# Altium schematic sheet 2-Power.schdoc(2-Power)
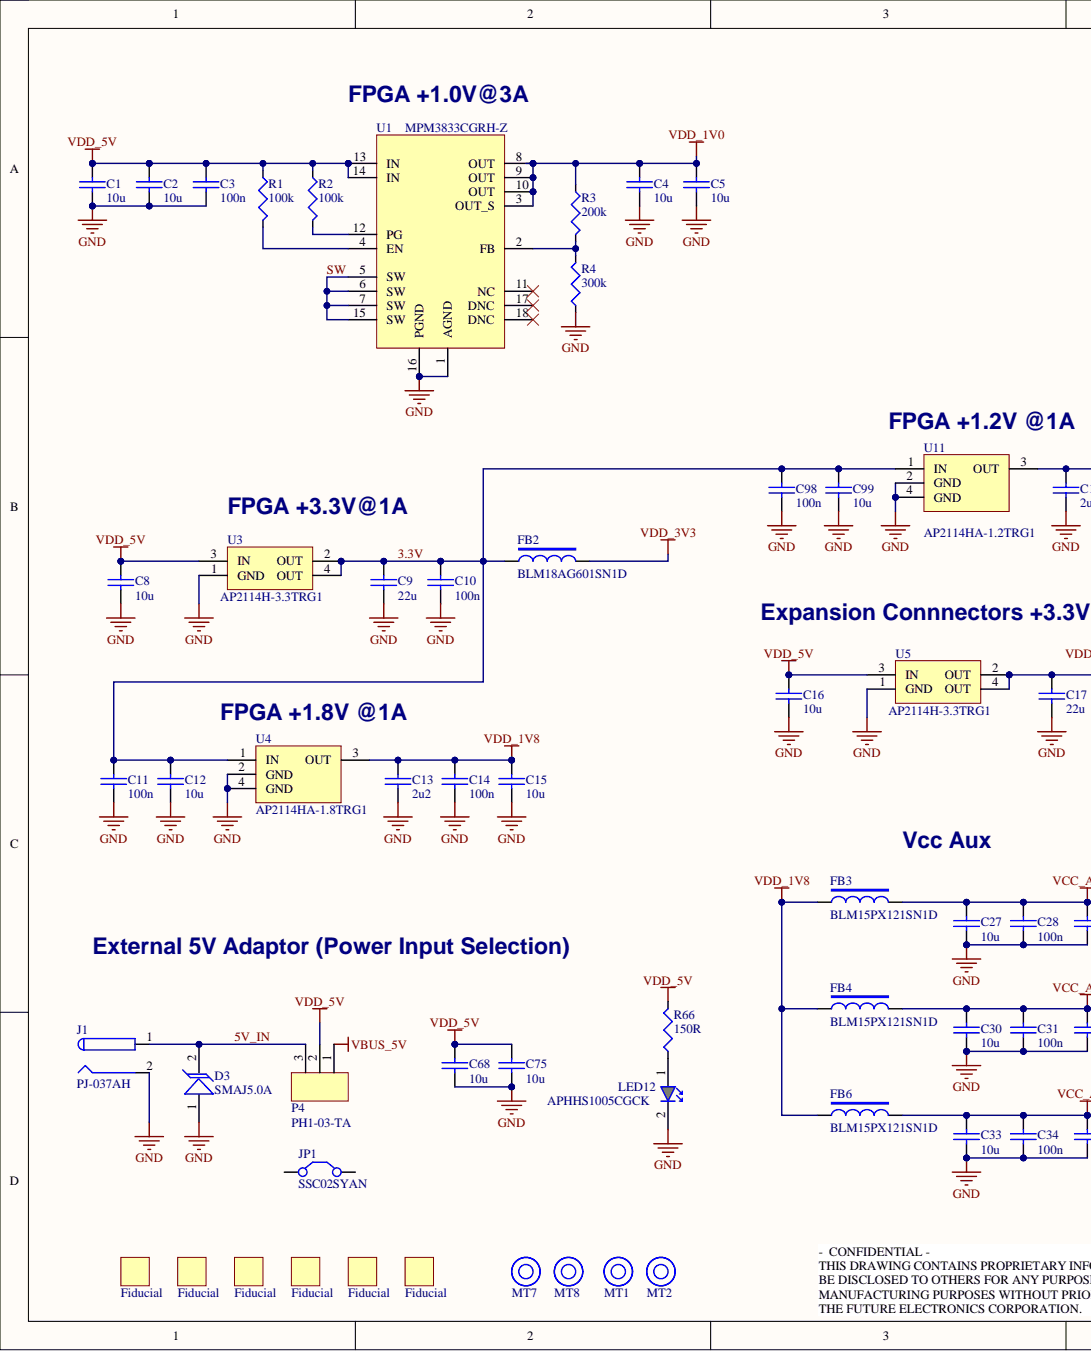
[diagram p0: 2-Power.schdoc, left]
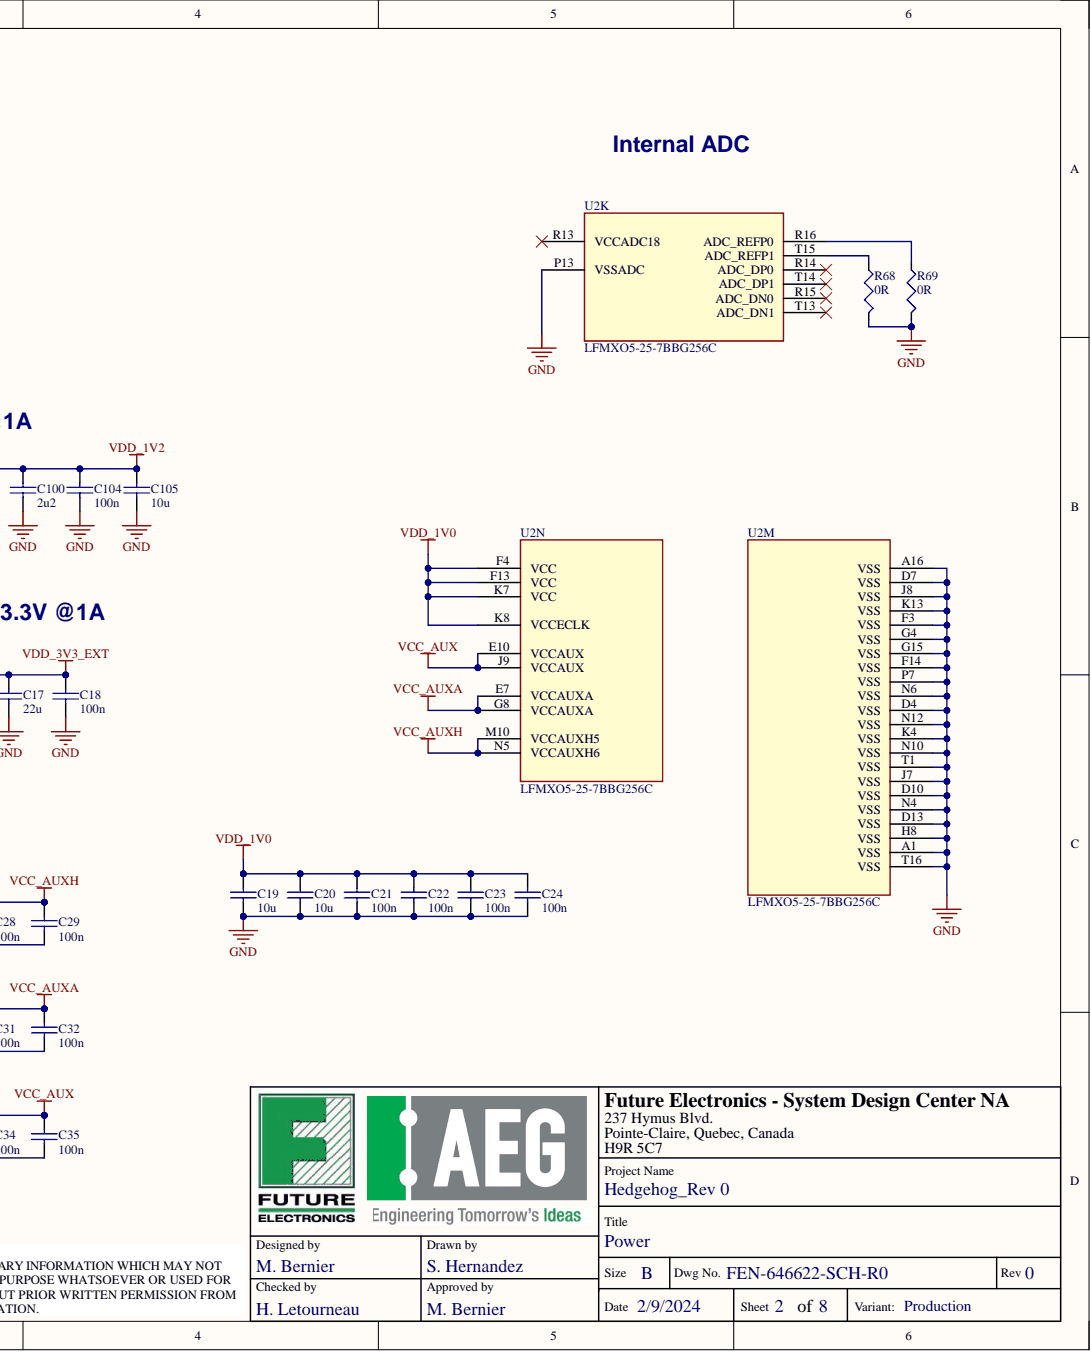
[diagram p1: 2-Power.schdoc, right]

## Parts
C1  value 10u  [1206]  (hidden comment: C-10uF-50V-Cer-1206_A)
C2  value 10u  [1206]  (hidden comment: C-10uF-50V-Cer-1206_A)
C3  value 100n  [0402]  (hidden comment: C-100nF-50V-Cer-0402)
C4  value 10u  [1206]  (hidden comment: C-10uF-50V-Cer-1206_A)
C5  value 10u  [1206]  (hidden comment: C-10uF-50V-Cer-1206_A)
C8  value 10u  [1206]  (hidden comment: C-10uF-50V-Cer-1206_A)
C9  value 22u  [0603]  (hidden comment: C-22uF-16V-Cer-0603)
C10  value 100n  [0402]  (hidden comment: C-100nF-50V-Cer-0402)
C11  value 100n  [0402]  (hidden comment: C-100nF-50V-Cer-0402)
C12  value 10u  [1206]  (hidden comment: C-10uF-50V-Cer-1206_A)
C13  value 2u2  [0805]  (hidden comment: C-2.2uF-10V-Cer-0805)
C14  value 100n  [0402]  (hidden comment: C-100nF-50V-Cer-0402)
C15  value 10u  [1206]  (hidden comment: C-10uF-50V-Cer-1206_A)
C16  value 10u  [1206]  (hidden comment: C-10uF-50V-Cer-1206_A)
C17  value 22u  [0603]  (hidden comment: C-22uF-16V-Cer-0603)
C18  value 100n  [0402]  (hidden comment: C-100nF-50V-Cer-0402)
C19  value 10u  [1206]  (hidden comment: C-10uF-50V-Cer-1206_A)
C20  value 10u  [1206]  (hidden comment: C-10uF-50V-Cer-1206_A)
C21  value 100n  [0402]  (hidden comment: C-100nF-50V-Cer-0402)
C22  value 100n  [0402]  (hidden comment: C-100nF-50V-Cer-0402)
C23  value 100n  [0402]  (hidden comment: C-100nF-50V-Cer-0402)
C24  value 100n  [0402]  (hidden comment: C-100nF-50V-Cer-0402)
C27  value 10u  [1206]  (hidden comment: C-10uF-50V-Cer-1206_A)
C28  value 100n  [0402]  (hidden comment: C-100nF-50V-Cer-0402)
C29  value 100n  [0402]  (hidden comment: C-100nF-50V-Cer-0402)
C30  value 10u  [1206]  (hidden comment: C-10uF-50V-Cer-1206_A)
C31  value 100n  [0402]  (hidden comment: C-100nF-50V-Cer-0402)
C32  value 100n  [0402]  (hidden comment: C-100nF-50V-Cer-0402)
C33  value 10u  [1206]  (hidden comment: C-10uF-50V-Cer-1206_A)
C34  value 100n  [0402]  (hidden comment: C-100nF-50V-Cer-0402)
C35  value 100n  [0402]  (hidden comment: C-100nF-50V-Cer-0402)
C68  value 10u  [1206]  (hidden comment: C-10uF-50V-Cer-1206_A)
C75  value 10u  [1206]  (hidden comment: C-10uF-50V-Cer-1206_A)
C98  value 100n  [0402]  (hidden comment: C-100nF-50V-Cer-0402)
C99  value 10u  [1206]  (hidden comment: C-10uF-50V-Cer-1206_A)
C100  value 2u2  [0805]  (hidden comment: C-2.2uF-10V-Cer-0805)
C104  value 100n  [0402]  (hidden comment: C-100nF-50V-Cer-0402)
C105  value 10u  [1206]  (hidden comment: C-10uF-50V-Cer-1206_A)
D3  MFR PN: SMAJ5.0A  [SMA]  (hidden comment: D-SMAJ5.0A)
FB2  MFR PN: BLM18AG601SN1D  [0603]  (hidden comment: FB-600Ohm@100MHz-0603)
FB3  MFR PN: BLM15PX121SN1D  [0402]  (hidden comment: FB-120Ohm@100MHz-0402)
FB4  MFR PN: BLM15PX121SN1D  [0402]  (hidden comment: FB-120Ohm@100MHz-0402)
FB6  MFR PN: BLM15PX121SN1D  [0402]  (hidden comment: FB-120Ohm@100MHz-0402)
FD1  FD-Fiducial  [Fiducial]  (value not drawn)  (no BOM)
FD2  FD-Fiducial  [Fiducial]  (value not drawn)  (no BOM)
FD3  FD-Fiducial  [Fiducial]  (value not drawn)  (no BOM)
FD4  FD-Fiducial  [Fiducial]  (value not drawn)  (no BOM)
FD5  FD-Fiducial  [Fiducial]  (value not drawn)  (no BOM)
FD6  FD-Fiducial  [Fiducial]  (value not drawn)  (no BOM)
J1  Future PN (TR): PJ-037AH  [J-PJ-037B]  (hidden comment: J-PJ-037AH)
JP1  MFR PN: SSC02SYAN  [No Footprint]  (hidden comment: JP-SSC02SYAN)  (mechanical)
LED12  Future PN (TR): APHHS1005CGCK  [0402-Kingbright]  (hidden comment: LED-APHHS1005CGCK)
MT1  Mount Hole, Unsupported, 2.5mm, Pan Head  [MTG 2.85mm hole]  (value not drawn)  (no BOM)
MT2  Mount Hole, Unsupported, 2.5mm, Pan Head  [MTG 2.85mm hole]  (value not drawn)  (no BOM)
MT7  Mount Hole, Unsupported, 2.5mm, Pan Head  [MTG 2.85mm hole]  (value not drawn)  (no BOM)
MT8  Mount Hole, Unsupported, 2.5mm, Pan Head  [MTG 2.85mm hole]  (value not drawn)  (no BOM)
P4  MFR PN: PH1-03-TA  [HDR100-3PIN-TH]  (hidden comment: P-PH1-03-TA)
R1  value 100k  [0402]  (hidden comment: R-100k-63mW-0402)
R2  value 100k  [0402]  (hidden comment: R-100k-63mW-0402)
R3  value 200k  [0402]  (hidden comment: R-200k-63mW-0402)
R4  value 300k  [0402]  (hidden comment: R-300k-63mW-0402)
R66  value 150R  [0402]  (hidden comment: R-150R-63mW-0402)
R68  value 0R  [0402]  (hidden comment: R-0R-63mW-0402)
R69  value 0R  [0402]  (hidden comment: R-0R-63mW-0402)
U1  Future PN (TR): MPM3833CGRH-Z  [QFN18_MPS]  (hidden comment: U-MPM3833CGRH-Z)
U2  MFR PN: LFMXO5-25-7BBG256C  [BBG256_LATTICE]  (hidden comment: U-LFMXO5-25-7BBG256C)  (parts K,M,N)
U3  Future PN (TR): AP2114H-3.3TRG1  [SOT223]  (hidden comment: U-AP2114H-3.3TRG1)
U4  MFR PN: AP2114HA-1.8TRG1  [SOT223]  (hidden comment: U-AP2114HA-1.8TRG1)
U5  Future PN (TR): AP2114H-3.3TRG1  [SOT223]  (hidden comment: U-AP2114H-3.3TRG1)
U11  MFR PN: AP2114HA-1.2TRG1  [SOT223]  (hidden comment: U-AP2114HA-1.2TRG1)

## Nets
GND: C1.2 C2.2 C3.2 C4.2 C5.2 C8.2 C9.2 C10.2 C11.2 C12.2 C13.2 C14.2 C15.2 C16.2 C17.2 C18.2 C19.2 C20.2 C21.2 C22.2 C23.2 C24.2 C27.2 C28.2 C29.2 C30.2 C31.2 C32.2 C33.2 C34.2 C35.2 C68.2 C75.2 C98.2 C99.2 C100.2 C104.2 C105.2 D3.1 J1.2 LED12.2 R4.1 R68.2 R69.2 U1.1(AGND) U1.16(PGND) U2.A1(VSS) U2.A16(VSS) U2.D4(VSS) U2.D7(VSS) U2.D10(VSS) U2.D13(VSS) U2.F3(VSS) U2.F14(VSS) U2.G4(VSS) U2.G15(VSS) U2.H8(VSS) U2.J7(VSS) U2.J8(VSS) U2.K4(VSS) U2.K13(VSS) U2.N4(VSS) U2.N6(VSS) U2.N10(VSS) U2.N12(VSS) U2.P7(VSS) U2.P13(VSSADC) U2.T1(VSS) U2.T16(VSS) U3.1(GND) U4.2(GND) U4.4(GND) U5.1(GND) U11.2(GND) U11.4(GND)
VBUS_5V: P4.1
VCC_AUX: C33.1 C34.1 C35.1 FB6.2 U2.E10(VCCAUX) U2.J9(VCCAUX)
VCC_AUXA: C30.1 C31.1 C32.1 FB4.2 U2.E7(VCCAUXA) U2.G8(VCCAUXA)
VCC_AUXH: C27.1 C28.1 C29.1 FB3.2 U2.M10(VCCAUXH5) U2.N5(VCCAUXH6)
VDD_1V0: C4.1 C5.1 C19.1 C20.1 C21.1 C22.1 C23.1 C24.1 R3.1 U1.3(OUT_S) U1.8(OUT) U1.9(OUT) U1.10(OUT) U2.F4(VCC) U2.F13(VCC) U2.K7(VCC) U2.K8(VCCECLK)
VDD_1V2: C100.1 C104.1 C105.1 U11.3(OUT)
VDD_1V8: C13.1 C14.1 C15.1 FB3.1 FB4.1 FB6.1 U4.3(OUT)
VDD_3V3: FB2.2
VDD_3V3_EXT: C17.1 C18.1 U5.2(OUT) U5.4(OUT)
VDD_5V: C1.1 C2.1 C3.1 C8.1 C16.1 C68.1 C75.1 P4.2 R1.1 R2.1 R66.2 U1.13(IN) U1.14(IN) U3.3(IN) U5.3(IN)
3.3V: C9.1 C10.1 C11.1 C12.1 C98.1 C99.1 FB2.1 U3.2(OUT) U3.4(OUT) U4.1(IN) U11.1(IN)
5V_IN: D3.2 J1.1 P4.3
SW: U1.5(SW) U1.6(SW) U1.7(SW) U1.15(SW)
NetLED12_1: LED12.1 R66.1
NetR1_2: R1.2 U1.4(EN)
NetR2_2: R2.2 U1.12(PG)
NetR3_2: R3.2 R4.2 U1.2(FB)
NetR68_1: R68.1 U2.T15(ADC_REFP1)
NetR69_1: R69.1 U2.R16(ADC_REFP0)

Not connected: JP1 1 2; U1 11(NC) 17(DNC) 18(DNC); U2 R13(VCCADC18) R14(ADC_DP0) R15(ADC_DN0) T13(ADC_DN1) T14(ADC_DP1)

## Library data of the parts
C1 C2 C4 C5 C8 C12 C15 C16 C19 C20 C27 C30 C33 C68 C75 C99 C105 = C-10uF-50V-Cer-1206_A: Cap 1206 SM X7R 50V 10%; MFR: SAMSUNG; MFR PN: CL31B106KBHNNNE; Future PN (CT): TBD; Future PN (TR): 12065C106KATN; Rating: 50V 10%; Component Kind: Standard; ComponentLink1Description: Datasheet; ComponentLink1URL: http://www.samsungsem.com/kr/support/product-search/mlcc/__icsFiles/afieldfile/2019/06/18/CL31B106KBHNNNE-19.pdf; Library Name: Future_Capacitors_V1.SCHLIB; Verified: AD112817
C3 C10 C11 C14 C18 C21-C24 C28 C29 C31 C32 C34 C35 C98 C104 = C-100nF-50V-Cer-0402: Cap 0402 SM X7R 50V 10%; MFR: TDK; MFR PN: C1005X7R1H104K050BB; Future PN (CT): 04025C104KATN-CT; Future PN (TR): 04025C104KATN; Rating: 50V 10%; Component Kind: Standard; ComponentLink1Description: Datasheet; ComponentLink1URL: http://images.ihscontent.net/vipimages/VipMasterIC/IC/TDKC/TDKC-S-A0000443042/TDKC-S-A0000443042-1.pdf; Library Name: Future_Capacitors_V1.SCHLIB; Verified: AD042616
C9 C17 = C-22uF-16V-Cer-0603: Cap 0603 SM X5R 16V 20%; MFR: SAMSUNG; MFR PN: CL10A226MO7JZNC; Future PN (CT): TBD; Future PN (TR): 0603YD226MATN; Rating: 16V 20%; Component Kind: Standard; ComponentLink1Description: Datasheet; ComponentLink1URL: https://product.samsungsem.com/mlcc/CL10A226MO7JZN.do; Verified: TBD; Library Name: Hedgehog_Rev0.SchLib
C13 C100 = C-2.2uF-10V-Cer-0805: Cap 0805 SM X7R 10V 10%; MFR: AVX; MFR PN: 0805ZC225KAT2A; Future PN (CT): 0805ZC225KATN-CT; Future PN (TR): 0805ZC225KATN; Rating: 10V 10%; Component Kind: Standard; ComponentLink1Description: Datasheet; ComponentLink1URL: http://datasheets.avx.com/X7RDielectric.pdf; Library Name: Future_Capacitors_V1.SCHLIB; Verified: CZ073119
D3 = D-SMAJ5.0A: Protection TVS Uni SMA SM 5.0Vr 9.2Vc; MFR: LITTELFUSE; Future PN (CT): SMAJ5.0ATR-CT; Future PN (TR): SMAJ5.0ATR; VModel: MMDDYY; Component Kind: Standard; ComponentLink1Description: Datasheet; ComponentLink1URL: http://www.littelfuse.com/~/media/Electronics/Datasheets/TVS_Diodes/Littelfuse_TVS_Diode_SMAJ_Datasheet.pdf.pdf; Library Name: Future_Semiconductors_V1.SchLib; Rating: 5.0Vr 9.2Vc; Verified: AD101614
FB2 = FB-600Ohm@100MHz-0603: Inductor EMI Suppression Filters 0603 SM 500mArt 600Ohm@100MHz; MFR: MURATA; Future PN (CT): BLM11A601SPT-CT; Future PN (TR): BLM11A601SPT; VModel: MMDDYY; Component Kind: Standard; ComponentLink1Description: Datasheet; ComponentLink1URL: https://www.murata.com/en-us/products/productdata/8796738650142/ENFA0003.pdf; Rating: 500mArt 600Ohm@100MHz; Verified: TBD; Library Name: Hedgehog_Rev0.SchLib
FB3 FB4 FB6 = FB-120Ohm@100MHz-0402: Inductor Ferrite Bead 0402 SM 2Art 55mOhmDC 120Ohm@100MHz; MFR: MURATA; Future PN (CT): TBD; VModel: MMDDYY; Component Kind: Standard; ComponentLink1Description: Datasheet; ComponentLink1URL: https://www.murata.com/en-us/products/productdata/8796740059166/ENFA0018.pdf; Future PN (TR): TBD; Rating: 2Art 55mOhmDC; Verified: TBD; Library Name: Hedgehog_Rev0.SchLib
FD1-FD6 = FD-Fiducial: Fiducial 40mil Copper 80mil exclusion; Future PN (CT): NONE; Future PN (TR): TBD; Value: 40/80mil; VModel: MMDDYY; Library Name: Future_Misc_V1.SchLib; MFR: NONE; MFR PN: NONE; Rating: Fiducial; Verified: AD090215
J1 = J-PJ-037AH: Connector DC Power Jack RA 2.0/6.5mm TH 24Vdc 5A; MFR: CUI; Future PN (CT): TBD; VModel: MMDDYY; Component Kind: Standard; ComponentLink1Description: Datasheet; ComponentLink1URL: http://www.cui.com/product/resource/pj-037ah.pdf; Library Name: Future_Connectors_V1.SCHLIB; MFR PN: PJ-037AH; Rating: 24Vdc 5A; Verified: AD091917
JP1 = JP-SSC02SYAN: Jumper  2.54mm; MFR: SULLINS; Future PN (CT): JLP-100-TG10; Future PN (TR): TBD; ComponentLink1Description: Datasheet; ComponentLink1URL: http://images.ihscontent.net/vipimages/VipMasterIC/IC/SUCS/SUCSS00149/SUCSS00149-1.pdf; Library Name: Future_Connectors_V1.SCHLIB; Rating: 2.54mm; Verified: AD051817
LED12 = LED-APHHS1005CGCK: LED Green AlGaInP 0402 SM 2.1-2.5Vf 30mA 574nm-pk 570nm-d 20mcd; MFR: KINGBRIGHT; Future PN (CT): APHHS1005CGCK-CT; Rating: 2.1Vf 20mA 75mW 570nm 50mcd; Component Kind: Standard; ComponentLink1Description: Datasheet; ComponentLink1URL: http://www.kingbrightusa.com/images/catalog/SPEC/APHHS1005CGCK.pdf; Library Name: Future_Opto_V1.SchLib; MFR PN: APHHS1005CGCK; Value: GREEN; Created by: R.Brack_2020-Mar-02
MT1 MT2 MT7 MT8 = 61-10036-2.5: Kickr Part Number: 61-10030; Description: Mount Hole, Unsupported, 2.5mm, Pan Head; Library Name: Hedgehog_Rev0.SchLib
P4 = P-PH1-03-TA: Connector 1Row Vert TH 1x3 2.54mm Pin Header; MFR: ADAMTECH; Future PN (CT): NSH-03SB-S2-T; Future PN (TR): TBD; VModel: MMDDYY; Component Kind: Standard; ComponentLink1Description: Datasheet; ComponentLink1URL: http://www.adam-tech.com/catalog/2012/page_312-315.pdf; Library Name: Future_Connectors_V1.SCHLIB; Rating: 1x3 2.54mm; Verified: AD073015
R1 R2 = R-100k-63mW-0402: Res 0402 SM 63mW 1% 100ppm; MFR: YAGEO; MFR PN: RC0402FR-07100KL; Future PN (CT): CR0402-1003FTR-CT; Future PN (TR): CR0402-1003FTR; Rating: 63mW 1%; Component Kind: Standard; ComponentLink1Description: Datasheet; ComponentLink1URL: https://www.yageo.com/upload/media/product/products/datasheet/rchip/PYu-RC_Group_51_RoHS_L_10.pdf; Library Name: Future_Resistors_V1.SCHLIB
R3 = R-200k-63mW-0402: Res 0402 SM 63mW 1% 100ppm; MFR: YAGEO; MFR PN: RC0402FR-07200KL; Future PN (CT): CR0402-2003FTR-CT; Future PN (TR): CR0402-2003FTR; Rating: 63mW 1%; Component Kind: Standard; ComponentLink1Description: Datasheet; ComponentLink1URL: http://www.yageo.com/documents/recent/PYu-RC0402_51_RoHS_L_6.pdf; Library Name: Future_Resistors_V1.SCHLIB
R4 = R-300k-63mW-0402: Res 0402 SM 63mW 1% 100ppm; MFR: YAGEO; MFR PN: RC0402FR-07300KL; Future PN (CT): CR0402-3003FTR-CT; Future PN (TR): CR0402-3003FTR; Rating: 63mW 1%; Component Kind: Standard; ComponentLink1Description: Datasheet; ComponentLink1URL: http://www.yageo.com/documents/recent/PYu-RC0402_51_RoHS_L_6.pdf; Library Name: Future_Resistors_V1.SCHLIB
R66 = R-150R-63mW-0402: Res 0402 SM 63mW 1% 100ppm; MFR: YAGEO; MFR PN: RC0402FR-07150RL; Future PN (CT): CR0402-1500FTR-CT; Future PN (TR): CR0402-1500FTR; Rating: 63mW 1%; Component Kind: Standard; ComponentLink1Description: Datasheet; ComponentLink1URL: http://www.yageo.com/documents/recent/PYu-RC0402_51_RoHS_L_6.pdf; Library Name: Future_Resistors_V1.SCHLIB
R68 R69 = R-0R-63mW-0402: Res 0402 SM 63mW; MFR: YAGEO; MFR PN: RC0402JR-070RL; Future PN (CT): CR0402-0000JTR-CT; Future PN (TR): CR0402-0000JTR; Component Kind: Standard; ComponentLink1Description: Datasheet; ComponentLink1URL: https://www.yageo.com/upload/media/product/products/datasheet/rchip/PYu-RC_Group_51_RoHS_L_10.pdf; Library Name: Future_Resistors_V1.SCHLIB; Rating: 63mW; Verified: AD062917
U1 = U-MPM3833CGRH-Z: PMIC Buck Step Dwn Regulator QFN-18 SM 2.75-6Vin 3Adc 1.15MHz; MFR: MPS; Future PN (CT): M3833CGRH-Z-SAMPLE-CT; VModel: MMDDYY; Component Kind: Standard; ComponentLink1Description: Datasheet; ComponentLink1URL: https://www.monolithicpower.com/en/documentview/productdocument/index/version/2/document_type/Datasheet/lang/en/sku/MPM3833CGRH-Z/document_id/4426/; MFR PN: MPM3833CGRH-Z; Rating: 2.75-6Vin 3Adc; Verified: TBD; Library Name: Hedgehog_Rev0.SchLib
U2 = U-LFMXO5-25-7BBG256C: FPGA MachXO5-NX BBG256 SM 0.95~1.05Vcore 450MHz; MFR: LATTICE; Future PN (CT): TBD; VModel: MMDDYY; Component Kind: Standard; ComponentLink1Description: Datasheet; ComponentLink1URL: https://www.latticesemi.com/-/media/LatticeSemi/Documents/MachXO5-NX/FPGA-DS-02102-1-0-MachXO5-NX-Family.ashx?document_id=53488; Future PN (TR): TBD; Rating: 0.95~1.05Vcore 450MHz; Verified: TBD; Library Name: Hedgehog_Rev0.SchLib
U3 U5 = U-AP2114H-3.3TRG1: PMIC LDO Regulator SOT223 SM 2.5-6Vop 3.3Vout 1A; MFR: DIODES; Future PN (CT): TBD; VModel: MMDDYY; Component Kind: Standard; ComponentLink1Description: Datasheet; ComponentLink1URL: https://www.diodes.com/assets/Datasheets/AP2114.pdf; Library Name: Future_Semiconductors_V1.SchLib; MFR PN: AP2114H-3.3TRG1; Rating: 3.3Vout 1A; Verified: AD012815
U4 = U-AP2114HA-1.8TRG1: PMIC LDO Fixed Outp SOT-223 SM 2.5-6.0Vin 1.8Vout 1Aout; MFR: DIODES; Future PN (CT): TBD; VModel: MMDDYY; Component Kind: Standard; ComponentLink1Description: Datasheet; ComponentLink1URL: https://www.diodes.com/assets/Datasheets/AP2114.pdf; Future PN (TR): TBD; Library Name: Future_Semiconductors_V1.SchLib; Rating: 2.5-6.0Vin 1.8Vout 1Aout; Verified: AD052215
U11 = U-AP2114HA-1.2TRG1: PMIC LDO Fixed Outp SOT-223 SM 2.5-6.0Vin 1.2Vout 1Aout; MFR: DIODES; Future PN (CT): TBD; Rating: 2.5-6.0Vin 1.8Vout 1Aout; VModel: MMDDYY; Component Kind: Standard; ComponentLink1Description: Datasheet; ComponentLink1URL: https://www.diodes.com/assets/Datasheets/AP2114.pdf; Future PN (TR): TBD; Library Name: Hedgehog_Rev0.SchLib
